# Altium schematic sheet Thermistor.SchDoc(Thermistor)
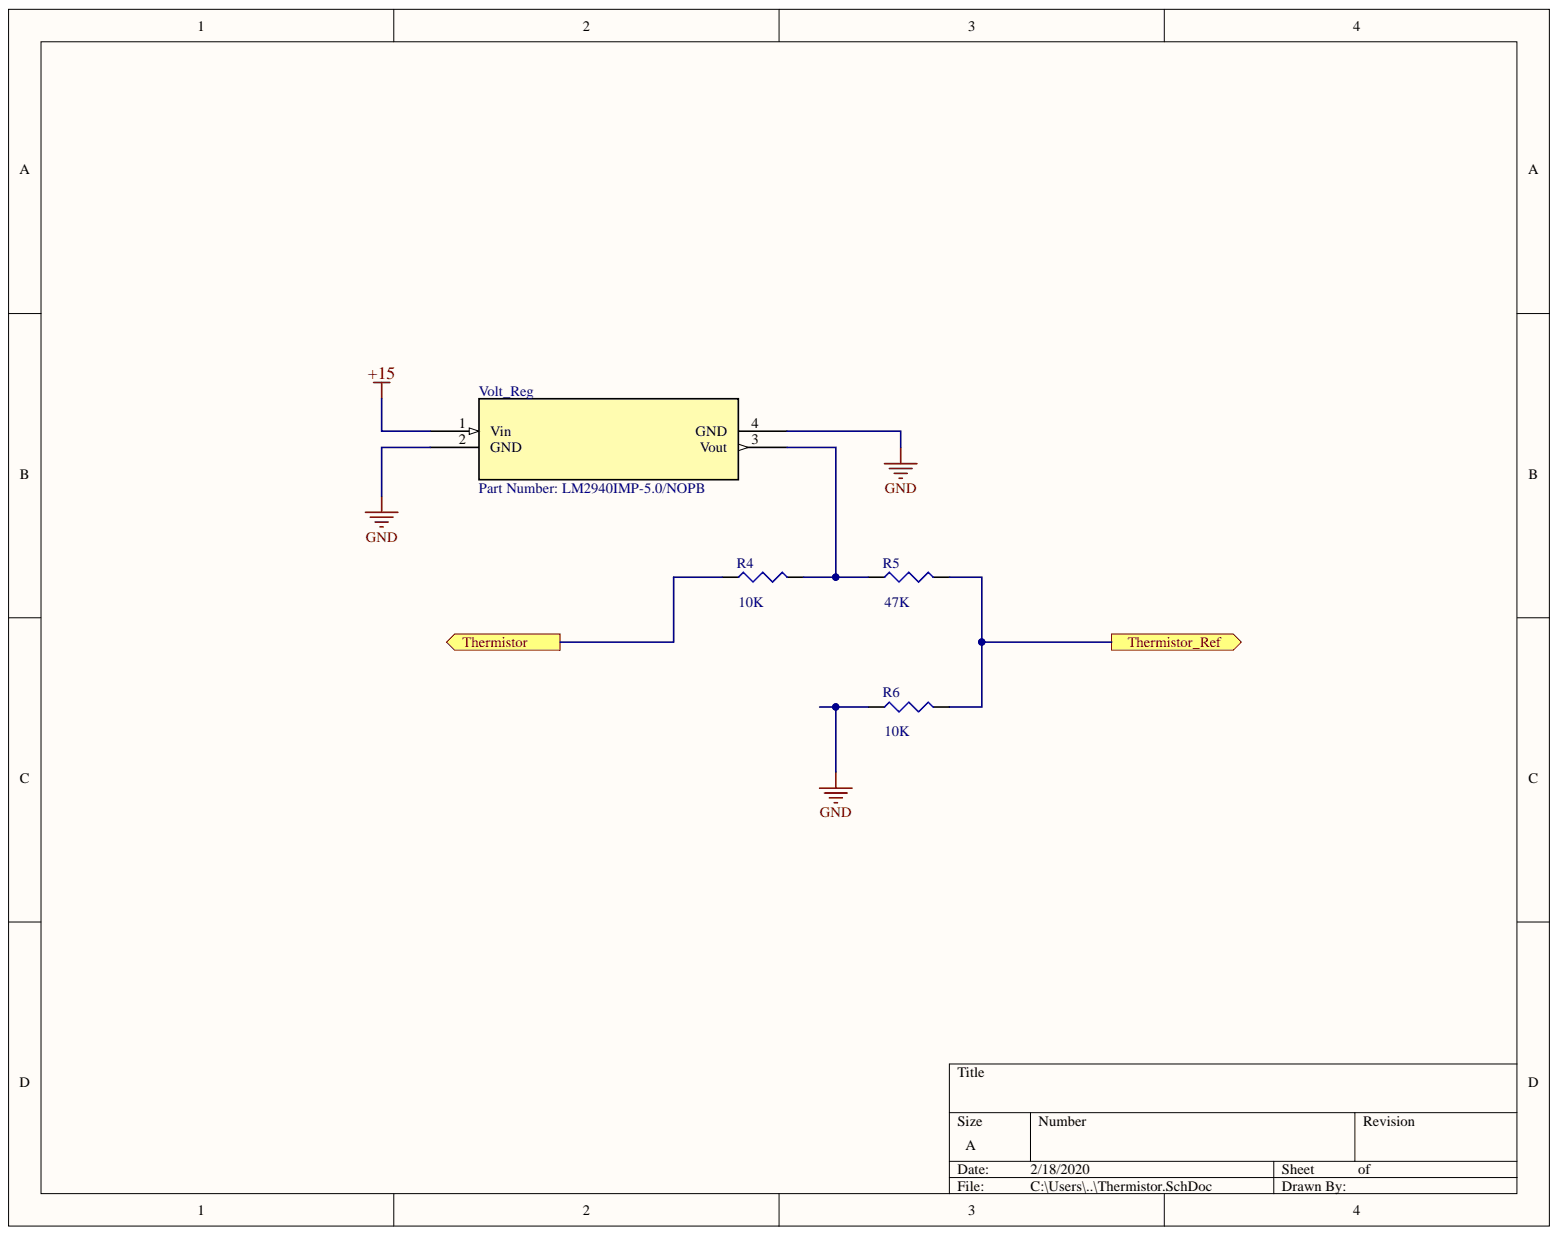
[diagram p0: Thermistor.SchDoc]

## Parts
R4  value 10K  [J1-0603]  (hidden comment: Res3)
R5  value 47K  [J1-0603]  (hidden comment: Res3)
R6  value 10K  [J1-0603]  (hidden comment: Res3)
Volt_Reg  Part Number: LM2940IMP-5.0/NOPB  Manufacturer_Part_Number: LM2940IMP-5.0/NOPB  [MP04A]

## Ports
Thermistor, Thermistor_Ref

## Nets
+15: Volt_Reg.1(Vin)
GND: R6.1 Volt_Reg.2(GND) Volt_Reg.4(GND)
Thermistor: R4.1 ; port Thermistor
Thermistor_Ref: R5.2 R6.2 ; port Thermistor_Ref
NetR4_2: R4.2 R5.1 Volt_Reg.3(Vout)

## Library data of the parts
R4-R6 = Res3: Resistor; Simulation: RESISTOR; Signal Integrity: Res; Published: 8-Jun-2000; PackageReference: J1-0603; PackageDescription: Chip Resistor; Library Name: Miscellaneous Devices.IntLib; LatestRevisionNote: IPC-7351 Footprint Added.; LatestRevisionDate: 29-May-2009; Component Kind: Standard; Publisher: Altium Limited
Volt_Reg = LM2940IMP-5.0/NO: No Description Available; Vendor: Texas Instruments; Built_By: EMA_UL_Team; Value: Value; Type: DEV; RefDes: RefDes; Library Name: 2019-12-04_00-30-34.SchLib; Component Kind: Standard; Copyright: Copyright (C) 2018 Accelerated Designs. All rights reserved
